# Altium schematic sheet Rikky-board_v0r0_POWER-SUPPLIES.SchDoc
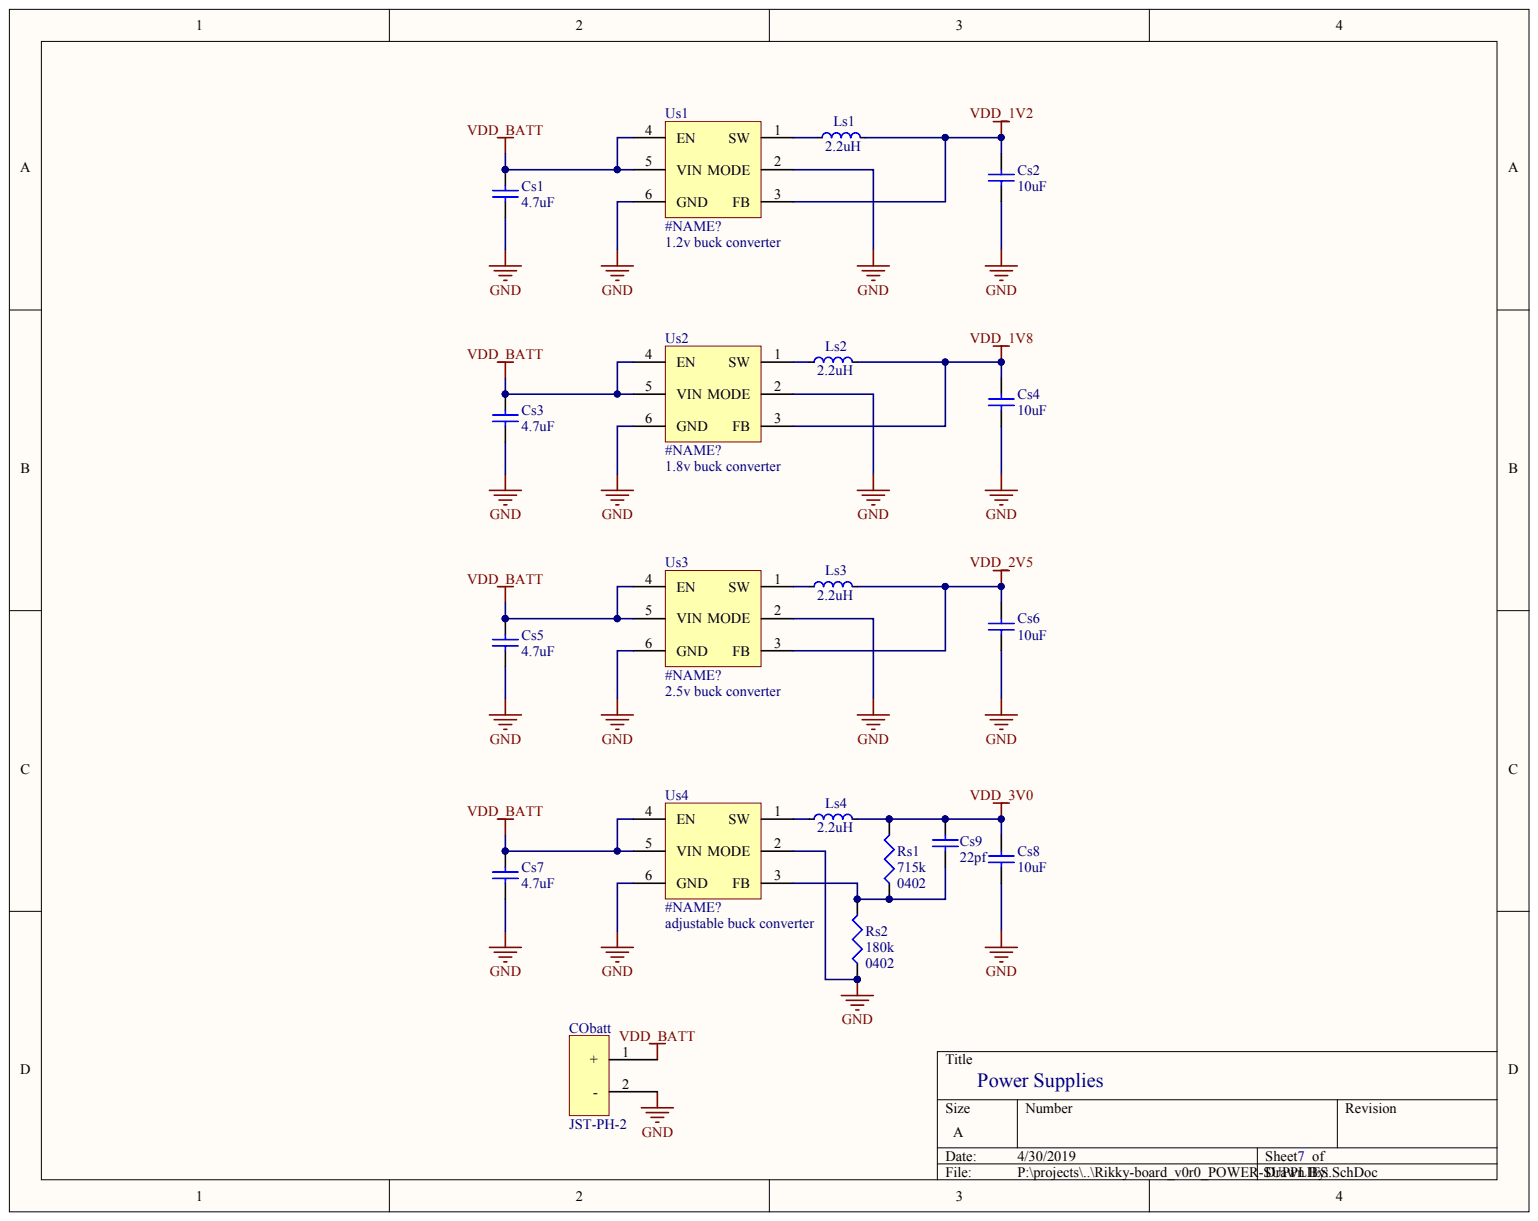
[diagram p0: Rikky-board_v0r0_POWER-SUPPLIES.SchDoc]

## Parts
CObatt  JST-PH-2
Cs1  value 4.7uF  (hidden comment: GRM188C81E475KE11D)
Cs2  value 10uF  (hidden comment: ZRB18AR61E106ME01L)
Cs3  value 4.7uF  (hidden comment: GRM188C81E475KE11D)
Cs4  value 10uF  (hidden comment: ZRB18AR61E106ME01L)
Cs5  value 4.7uF  (hidden comment: GRM188C81E475KE11D)
Cs6  value 10uF  (hidden comment: ZRB18AR61E106ME01L)
Cs7  value 4.7uF  (hidden comment: GRM188C81E475KE11D)
Cs8  value 10uF  (hidden comment: ZRB18AR61E106ME01L)
Cs9  value 22pf  (hidden comment: GRM1555C1H220FA01D)
Ls1  value 2.2uH
Ls2  value 2.2uH
Ls3  value 2.2uH
Ls4  value 2.2uH
Rs1  value 715k  Package: 0402  (hidden comment: ERJ-1GEF7153C)
Rs2  value 180k  Package: 0402  (hidden comment: ERJ-1GEF1803C)
Us1  Note: 1.2v buck converter  (hidden comment: TPS62262TDRVRQ1)
Us2  Note: 1.8v buck converter  (hidden comment: TPS62261TDRVRQ1)
Us3  Note: 2.5v buck converter  (hidden comment: TPS62263TDRVRQ1)
Us4  Note: adjustable buck converter  (hidden comment: TPS62260DRVT)

## Nets
GND: CObatt.2 Cs1.1 Cs2.1 Cs3.1 Cs4.1 Cs5.1 Cs6.1 Cs7.1 Cs8.1 Rs2.1 Us1.2(MODE) Us1.6(GND) Us1.7 Us2.2(MODE) Us2.6(GND) Us2.7 Us3.2(MODE) Us3.6(GND) Us3.7 Us4.2(MODE) Us4.6(GND) Us4.7
VDD_1V2: Cs2.2 Ls1.1 Us1.3(FB)
VDD_1V8: Cs4.2 Ls2.1 Us2.3(FB)
VDD_2V5: Cs6.2 Ls3.1 Us3.3(FB)
VDD_3V0: Cs8.2 Cs9.2 Ls4.1 Rs1.2
VDD_BATT: CObatt.1 Cs1.2 Cs3.2 Cs5.2 Cs7.2 Us1.4(EN) Us1.5(VIN) Us2.4(EN) Us2.5(VIN) Us3.4(EN) Us3.5(VIN) Us4.4(EN) Us4.5(VIN)
NetCs9_1: Cs9.1 Rs1.1 Rs2.2 Us4.3(FB)
NetLs1_2: Ls1.2 Us1.1(SW)
NetLs2_2: Ls2.2 Us2.1(SW)
NetLs3_2: Ls3.2 Us3.1(SW)
NetLs4_2: Ls4.2 Us4.1(SW)

## Library data of the parts
CObatt = (no symbol name): Manufacturer: JST; Manufacturer P/N: B2B-PH-K-S(LF)(SN); Supplier: Digikey; Supplier P/N: 455-1704-ND
Cs1-Cs9 = (no symbol name): Manufacturer: Murata; Supplier: Digikey
  Cs1 Cs3 Cs5 Cs7: Supplier PN: 490-7199-1-ND; Manufacturer PN: GRM188C81E475KE11D
  Cs2 Cs4 Cs6 Cs8: Supplier PN: 490-10991-1-ND; Manufacturer PN: ZRB18AR61E106ME01L
  Cs9: Supplier PN: 490-8589-1-ND; Manufacturer PN: GRM1555C1H220FA01D
Ls1-Ls4 = (no symbol name): Manufacturer PN: LQM2HPN2R2MJ0L; Manufacturer: Murata; Supplier PN: 490-6700-6-ND; Supplier: Digikey
Rs1 Rs2 = (no symbol name): Manufacturer: Panasonic; Power: 1/20W; Supplier: Digikey
  Rs1: Manufacturer PN: ERJ-1GEF7153C; Supplier P/N: P715KABCT-ND
  Rs2: Manufacturer PN: ERJ-1GEF1803C; Supplier P/N: P180KABCT-ND
Us1-Us4 = (no symbol name): Manufacturer: TI; Supplier: Digikey
  Us1: Supplier PN: 296-25730-1-ND; Manufacturer PN: TPS62262TDRVRQ1
  Us2: Supplier PN: 296-25729-1-ND; Manufacturer PN: TPS62261TDRVRQ1
  Us3: Supplier PN: 296-25731-1-ND; Manufacturer PN: TPS62263TDRVRQ1
  Us4: Supplier PN: 296-22470-1-ND; Manufacturer PN: TPS62260DRVT
